# Altium schematic sheet WRO_Genralsquematic.SchDoc
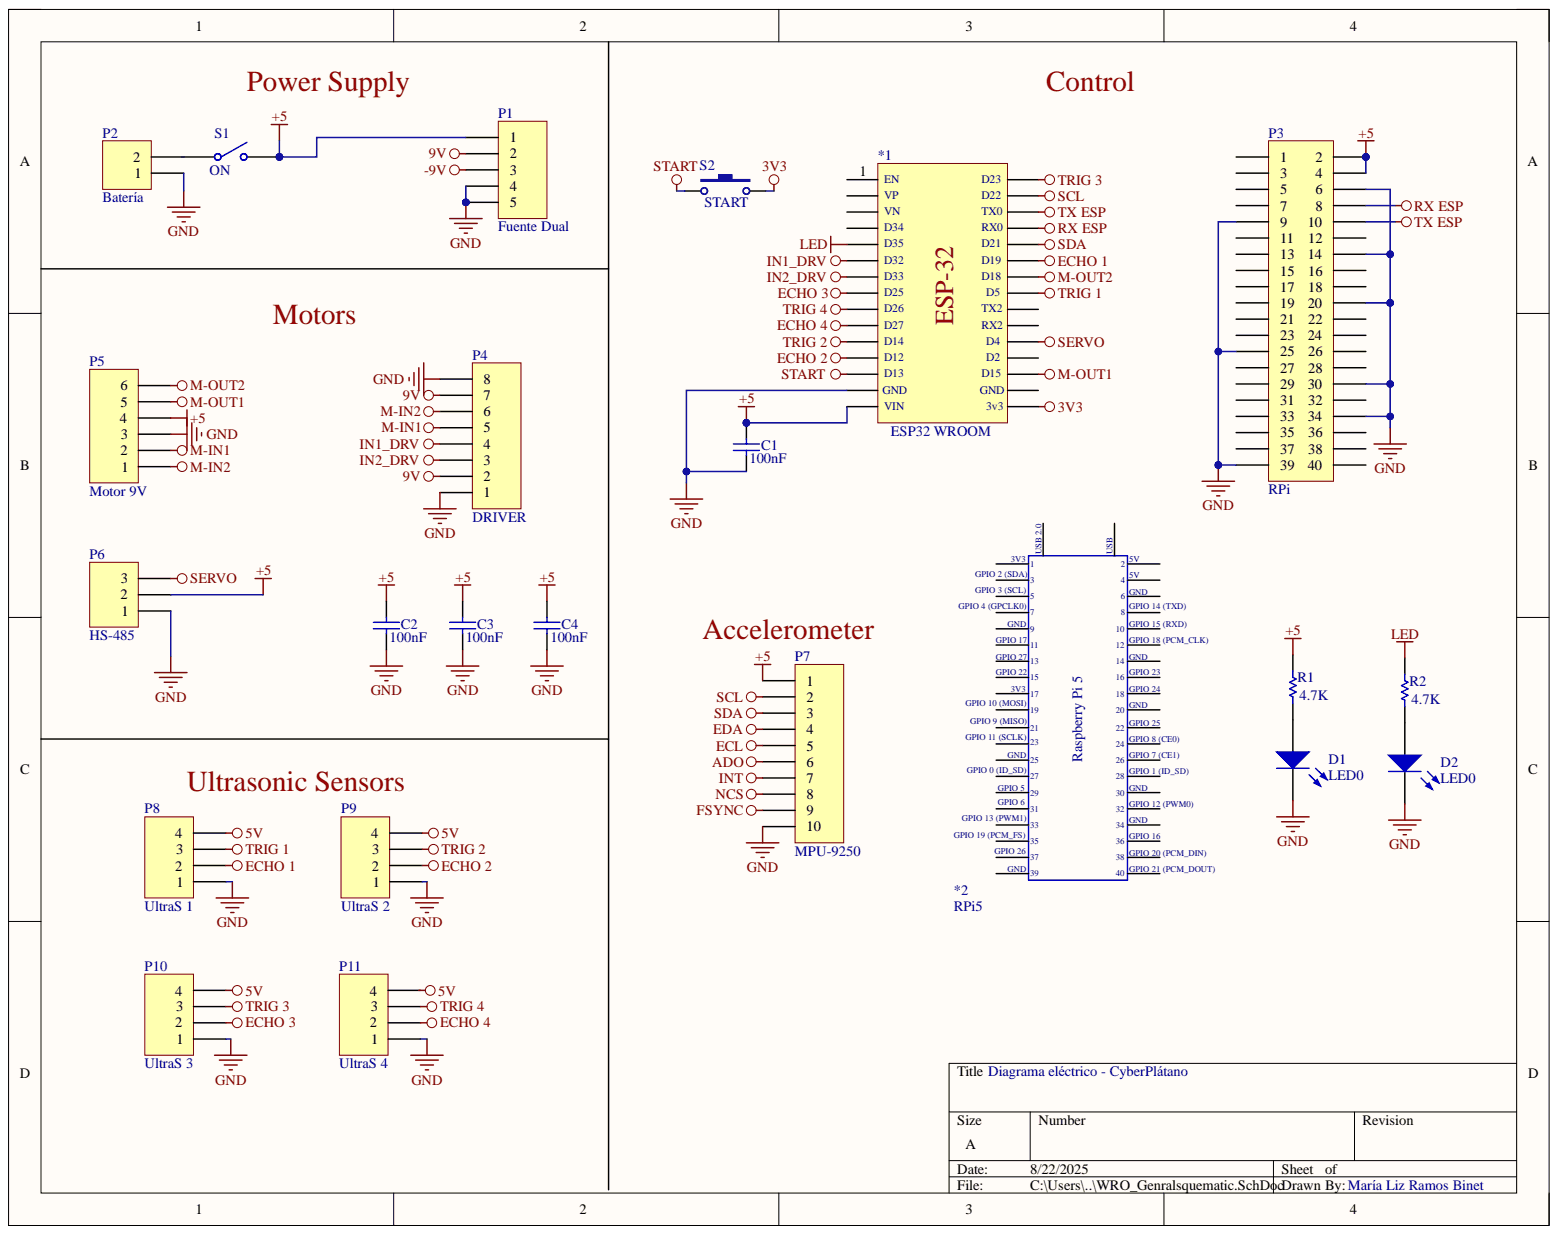
[diagram p0: WRO_Genralsquematic.SchDoc]

## Parts
*1  ESP32 WROOM  [ESP32 WROOM]
*2  RPi5  [RPi 5 - header]
C1  value 100nF  [Capacitor 02]  (hidden comment: Cap)
C2  value 100nF  [Capacitor 02]  (hidden comment: Cap)
C3  value 100nF  [Capacitor 02]  (hidden comment: Cap)
C4  value 100nF  [Capacitor 02]  (hidden comment: Cap)
D1  LED0  [LED-0]
D2  LED0  [LED-0]
P1  Fuente Dual  [TERMINAL BLOCK 5]
P2  Batería  [Terminal Block 2]
P3  RPi  [HDR2X20]
P4  DRIVER  [HDR1X8]
P5  Motor 9V  [TERMINAL BLOCK 6]
P6  HS-485  [Terminal Block 3]
P7  MPU-9250  [HDR1X10]
P8  UltraS 1  [TERMINAL BLOCK  4]
P9  UltraS 2  [TERMINAL BLOCK  4]
P10  UltraS 3  [TERMINAL BLOCK  4]
P11  UltraS 4  [TERMINAL BLOCK  4]
R1  value 4.7K  [AXIAL-0.3]  (hidden comment: Res1)
R2  value 4.7K  [AXIAL-0.3]  (hidden comment: Res1)
S1  ON  [SPST-2]
S2  START  [Push-to-Make]

## Nets
3V3: *1.16(3v3) S2.2
5V: *1.15(VIN) C1.2 C2.2 C3.2 C4.2 P1.1 P3.2 P3.4 P5.4 P6.2 P7.1 P8.4 P9.4 P10.4 R1.1 S1.2
9V: P1.2 P4.2 P4.7
-9V: P1.3
ADO: P7.6
ECHO 1: *1.25(D19) P8.2
ECHO 2: *1.12(D12) P9.2
ECHO 3: *1.8(D25) P10.2
ECHO 4: *1.10(D27) P11.2
ECL: P7.5
EDA: P7.4
FSYNC: P7.9
GND: *1.14(GND) C1.1 C2.1 C3.1 C4.1 D1.2 D2.2 P1.4 P1.5 P2.1 P3.6 P3.9 P3.14 P3.20 P3.25 P3.30 P3.34 P3.39 P4.1 P4.8 P5.3 P6.1 P7.10 P8.1 P9.1 P10.1 P11.1
IN1_DRV: *1.6(D32) P4.4
IN2_DRV: *1.7(D33) P4.3
INT: P7.7
LED: *1.5(D35) R2.1
M-IN1: P4.5 P5.2
M-IN2: P4.6 P5.1
M-OUT1: *1.18(D15) P5.5
M-OUT2: *1.24(D18) P5.6
NCS: P7.8
RX ESP: *1.27(RX0) P3.8
SCL: *1.29(D22) P7.2
SDA: *1.26(D21) P7.3
SERVO: *1.20(D4) P6.3
START: *1.13(D13) S2.1
TRIG 1: *1.23(D5) P8.3
TRIG 2: *1.11(D14) P9.3
TRIG 3: *1.30(D23) P10.3
TRIG 4: *1.9(D26) P11.3
TX ESP: *1.28(TX0) P3.10
NetD1_1: D1.1 R1.2
NetD2_1: D2.1 R2.2
NetP2_2: P2.2 S1.1

Not connected: *1 1(EN) 2(VP) 3(VN) 4(D34) 17(GND) 19(D2) 21(RX2) 22(TX2); *2 44 45 46 47 48; P3 1 3 5 7 11 12 13 15 16 17 18 19 21 22 23 24 26 27 28 29 31 32 33 35 36 37 38 40; P11 4

## Library data of the parts
C1-C4 = Cap: Capacitor; Component Kind: Standard; LatestRevisionDate: 17-Jul-2002; LatestRevisionNote: Re-released for DXP Platform.; Library Name: Miscellaneous Devices.IntLib; PackageDescription: Radial Cap, Thru-Hole; 2 Leads; 0.3 in Pin Spacing; PackageReference: RAD-0.3; Published: 8-Jun-2000; Signal Integrity: Cap; Simulation: CAP; Publisher: Altium Limited
D1 D2 = LED0: Typical INFRARED GaAs LED; Component Kind: Standard; LatestRevisionDate: 08-Jul-2005; LatestRevisionNote: Mech Layer 1 removed.; Library Name: Miscellaneous Devices.IntLib; PackageDescription: LED; 2 Leads; PackageReference: LED-0; Published: 8-Jun-2000; Simulation: LED0; Publisher: Altium Limited
P1 = Header 5: Header, 5-Pin; Component Kind: Standard; LatestRevisionDate: 17-Jul-2002; LatestRevisionNote: Re-released for DXP Platform.; Library Name: Miscellaneous Connectors.IntLib; Signal Integrity: Connector; Publisher: Altium Limited
P2 = Header 2: Header, 2-Pin; Component Kind: Standard; LatestRevisionDate: 17-Jul-2002; LatestRevisionNote: Re-released for DXP Platform.; Library Name: Miscellaneous Connectors.IntLib; Signal Integrity: Connector; Publisher: Altium Limited
P3 = Header 20X2: Header, 20-Pin, Dual row; Component Kind: Standard; LatestRevisionDate: 17-Jul-2002; LatestRevisionNote: Re-released for DXP Platform.; Library Name: Miscellaneous Connectors.IntLib; Signal Integrity: Connector; Publisher: Altium Limited
P4 = Header 8: Header, 8-Pin; Component Kind: Standard; LatestRevisionDate: 17-Jul-2002; LatestRevisionNote: Re-released for DXP Platform.; Library Name: Miscellaneous Connectors.IntLib; Signal Integrity: Connector; Publisher: Altium Limited
P5 = Header 6: Header, 6-Pin; Component Kind: Standard; LatestRevisionDate: 17-Jul-2002; LatestRevisionNote: Re-released for DXP Platform.; Library Name: Miscellaneous Connectors.IntLib; Signal Integrity: Connector; Publisher: Altium Limited
P6 = Header 3: Header, 3-Pin; Component Kind: Standard; LatestRevisionDate: 17-Jul-2002; LatestRevisionNote: Re-released for DXP Platform.; Library Name: Miscellaneous Connectors.IntLib; Signal Integrity: Connector; Publisher: Altium Limited
P7 = Header 10: Header, 10-Pin; Component Kind: Standard; LatestRevisionDate: 17-Jul-2002; LatestRevisionNote: Re-released for DXP Platform.; Library Name: Miscellaneous Connectors.IntLib; Signal Integrity: Connector; Publisher: Altium Limited
P8-P11 = Header 4: Header, 4-Pin; Component Kind: Standard; LatestRevisionDate: 17-Jul-2002; LatestRevisionNote: Re-released for DXP Platform.; Library Name: Miscellaneous Connectors.IntLib; Signal Integrity: Connector; Publisher: Altium Limited
R1 R2 = Res1: Resistor; Component Kind: Standard; LatestRevisionDate: 17-Jul-2002; LatestRevisionNote: Re-released for DXP Platform.; Library Name: Miscellaneous Devices.IntLib; PackageDescription: Axial Device, Thru-Hole; 2 Leads; 0.3 in Pin Spacing; PackageReference: AXIAL-0.3; Published: 8-Jun-2000; Signal Integrity: Res; Simulation: RESISTOR; Publisher: Altium Limited
S1 = SW-SPST: Single-Pole, Single-Throw Switch; Component Kind: Standard; LatestRevisionDate: 17-Jul-2002; LatestRevisionNote: Re-released for DXP Platform.; Library Name: Miscellaneous Devices.IntLib; PackageDescription: Switch; 2 Leads; PackageReference: SPST-2; Published: 24-Mar-1999; Publisher: Altium Limited
S2 = SW-PB 1: Push-to-Make; Component Kind: Standard; LatestRevisionDate: 17-Jul-2002; LatestRevisionNote: Re-released for DXP Platform.; Library Name: Max Library.IntLib; PackageReference: SPST-2; Published: 24-Mar-1999; Publisher: Altium Limited
*1 = ESP32 WROOM: Component Kind: Standard; Library Name: ESP8266.SchLib
*2 = RPi5: Component Kind: Standard; Library Name: MiniESP32.SchLib
